# Altium schematic sheet MCU.SchDoc
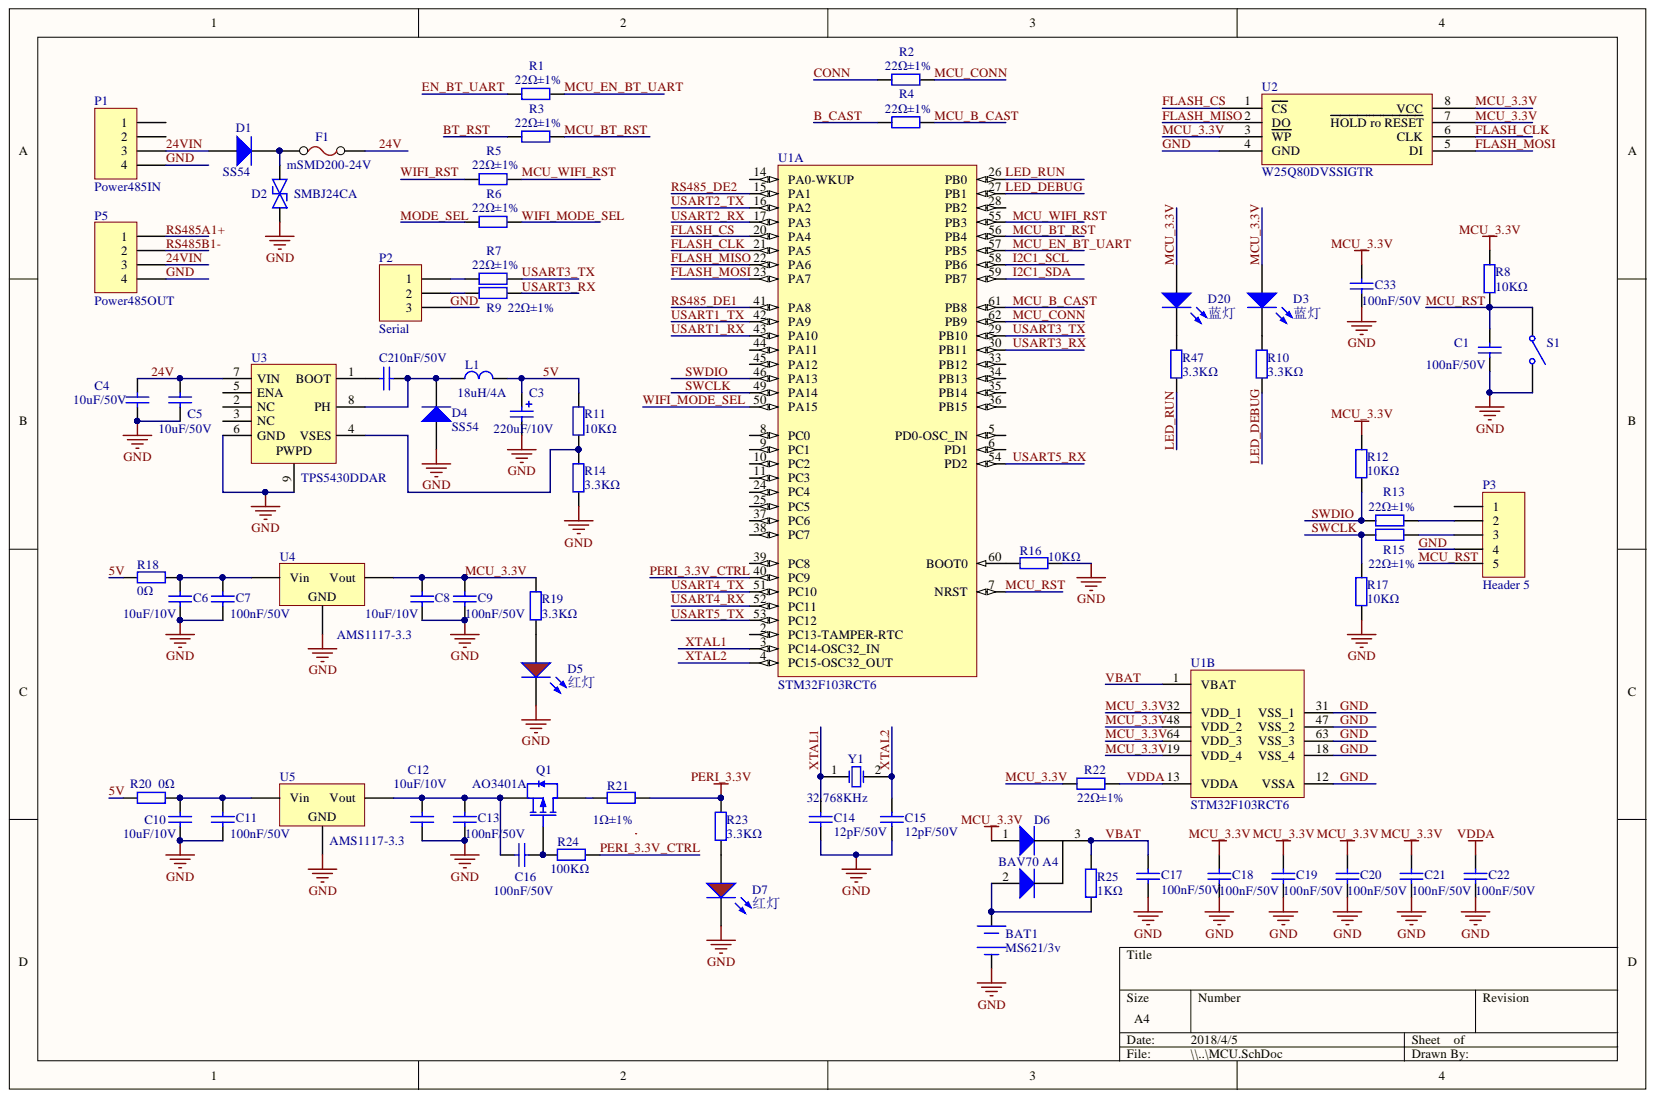
[diagram p0: MCU.SchDoc]

## Parts
BAT1  MS621/3v  [MS621]
C1  100nF/50V  [0603_C]
C2  10nF/50V  [0603_C]
C3  220uF/10V  [LC-CASE-D_7343]
C4  10uF/50V  [1206_C]
C5  10uF/50V  [1206_C]
C6  10uF/10V  [0603_C]
C7  100nF/50V  [0603_C]
C8  10uF/10V  [0603_C]
C9  100nF/50V  [0603_C]
C10  10uF/10V  [0603_C]
C11  100nF/50V  [0603_C]
C12  10uF/10V  [0603_C]
C13  100nF/50V  [0603_C]
C14  12pF/50V  [0603_C]
C15  12pF/50V  [0603_C]
C16  100nF/50V  [0603_C]
C17  100nF/50V  [0603_C]
C18  100nF/50V  [0603_C]
C19  100nF/50V  [0603_C]
C20  100nF/50V  [0603_C]
C21  100nF/50V  [0603_C]
C22  100nF/50V  [0603_C]
C33  100nF/50V  [0603_C]
D1  SS54  [SMA(DO-214AC)_S1]
D2  SMBJ24CA  [SMB(DO-214AA)_S1]
D3  蓝灯  [0603_LED_S1]
D4  SS54  [SMA(DO-214AC)_S1]
D5  红灯  [0603_LED_S1]
D6  BAV70 A4  [SOT-23(SOT-23-3)]
D7  红灯  [0603_LED_S1]
D20  蓝灯  [0603_LED_S1]
F1  mSMD200-24V  [LC-1812]
L1  18uH/4A  [L127]
P1  Power485IN  [15EDG-3.81MM-4P]
P2  Serial  [HDR1X3]
P3  Header 5  [HDR1X5]
P5  Power485OUT  [15EDG-3.81MM-4P]
Q1  AO3401A  [SOT-23-3L]
R1  22Ω±1%  [0603_R]
R2  22Ω±1%  [0603_R]
R3  22Ω±1%  [0603_R]
R4  22Ω±1%  [0603_R]
R5  22Ω±1%  [0603_R]
R6  22Ω±1%  [0603_R]
R7  22Ω±1%  [0603_R]
R8  10KΩ  [0603_R]
R9  22Ω±1%  [0603_R]
R10  3.3KΩ  [0603_R]
R11  10KΩ  [0603_R]
R12  10KΩ  [0603_R]
R13  22Ω±1%  [0603_R]
R14  3.3KΩ  [0603_R]
R15  22Ω±1%  [0603_R]
R16  10KΩ  [0603_R]
R17  10KΩ  [0603_R]
R18  0Ω  [0603_R]
R19  3.3KΩ  [0603_R]
R20  0Ω  [0603_R]
R21  1Ω±1%  [0603_R]
R22  22Ω±1%  [0603_R]
R23  3.3KΩ  [0603_R]
R24  100KΩ  [0603_R]
R25  1KΩ  [0603_R]
R47  3.3KΩ  [0603_R]
S1  (no value)  [KEY_6X6]
U1  STM32F103RCT6  [LQFP-64_10x10x05P]  (parts A,B)
U2  W25Q80DVSSIGTR  [SOIC-8_208mil]
U3  TPS5430DDAR  [SOP-8_EP_150mil_GND]
U4  AMS1117-3.3  [SOT-223]
U5  AMS1117-3.3  [SOT-223]
Y1  32.768KHz  [SMD-3215_2P FC - 135]

## Nets
PERI_3.3V: R21.2 R23.2
5V: C3.1 L1.2 R11.2 R18.1 R20.1
24V: C4.2 C5.2 F1.2 U3.7(VIN)
24VIN: D1.1 P1.3 P5.3
B_CAST: R4.1
BT_RST: R3.1
CONN: R2.1
EN_BT_UART: R1.1
FLASH_CLK: U1.21(PA5) U2.6(CLK)
FLASH_CS: U1.20(PA4) U2.1(CS)
FLASH_MISO: U1.22(PA6) U2.2(DO)
FLASH_MOSI: U1.23(PA7) U2.5(DI)
GND: BAT1.2 C1.1 C3.2 C4.1 C5.1 C6.1 C7.1 C8.1 C9.1 C10.1 C11.1 C12.1 C13.1 C14.1 C15.1 C17.1 C18.1 C19.1 C20.1 C21.1 C22.1 C33.1 D2.1 D4.1 D5.K D7.K P1.4 P2.3 P3.4 P5.4 R14.1 R16.1 R17.1 S1.2 U1.12(VSSA) U1.18(VSS_4) U1.31(VSS_1) U1.47(VSS_2) U1.63(VSS_3) U2.4(GND) U3.6(GND) U3.9 U4.1 U5.1
I2C1_SCL: U1.58(PB6)
I2C1_SDA: U1.59(PB7)
LED_DEBUG: R10.1 U1.27(PB1)
LED_RUN: R47.1 U1.26(PB0)
MCU_3.3V: C8.2 C9.2 C18.2 C19.2 C20.2 C21.2 C33.2 D3.A D6.1 D20.A R8.2 R12.2 R19.2 R22.1 U1.19(VDD_4) U1.32(VDD_1) U1.48(VDD_2) U1.64(VDD_3) U2.3(WP) U2.7(HOLD_ro_RESET) U2.8(VCC) U4.2
MCU_B_CAST: R4.2 U1.61(PB8)
MCU_BT_RST: R3.2 U1.56(PB4)
MCU_CONN: R2.2 U1.62(PB9)
MCU_EN_BT_UART: R1.2 U1.57(PB5)
MCU_RST: C1.2 P3.5 R8.1 S1.1 U1.7(NRST)
MCU_WIFI_RST: R5.2 U1.55(PB3)
MODE_SEL: R6.1
PERI_3.3V_CTRL: R24.2 U1.40(PC9)
RS485_DE1: U1.41(PA8)
RS485_DE2: U1.15(PA1)
RS485A1+: P5.1
RS485B1-: P5.2
SWCLK: R15.1 R17.2 U1.49(PA14)
SWDIO: R12.1 R13.1 U1.46(PA13)
USART1_RX: U1.43(PA10)
USART1_TX: U1.42(PA9)
USART2_RX: U1.17(PA3)
USART2_TX: U1.16(PA2)
USART3_RX: R9.2 U1.30(PB11)
USART3_TX: R7.2 U1.29(PB10)
USART4_RX: U1.52(PC11)
USART4_TX: U1.51(PC10)
USART5_RX: U1.54(PD2)
USART5_TX: U1.53(PC12)
VBAT: C17.2 D6.3 R25.2 U1.1(VBAT)
VDDA: C22.2 R22.2 U1.13(VDDA)
WIFI_MODE_SEL: R6.2 U1.50(PA15)
WIFI_RST: R5.1
XTAL1: C14.2 U1.3(PC14-OSC32_IN) Y1.1
XTAL2: C15.2 U1.4(PC15-OSC32_OUT) Y1.2
NetBAT1_1: BAT1.1 D6.2 R25.1
NetC2_1: C2.1 D4.2 L1.1 U3.8(PH)
NetC2_2: C2.2 U3.1(BOOT)
NetC6_2: C6.2 C7.2 R18.2 U4.3
NetC10_2: C10.2 C11.2 R20.2 U5.3
NetC12_2: C12.2 C13.2 C16.1 Q1.2 U5.2
NetC16_2: C16.2 Q1.1 R24.1
NetD1_2: D1.2 D2.2 F1.1
NetD3_K: D3.K R10.2
NetD5_A: D5.A R19.1
NetD7_A: D7.A R23.1
NetD20_K: D20.K R47.2
NetP2_1: P2.1 R7.1
NetP2_2: P2.2 R9.1
NetP3_2: P3.2 R13.2
NetP3_3: P3.3 R15.2
NetQ1_3: Q1.3 R21.1
NetR11_1: R11.1 R14.2 U3.4(VSES)
NetR16_2: R16.2 U1.60(BOOT0)

Not connected: P1 1 2; P3 1; U1 2(PC13-TAMPER-RTC) 5(PD0-OSC_IN) 6(PD1) 8(PC0) 9(PC1) 10(PC2) 11(PC3) 14(PA0-WKUP) 24(PC4) 25(PC5) 28(PB2) 33(PB12) 34(PB13) 35(PB14) 36(PB15) 37(PC6) 38(PC7) 39(PC8) 44(PA11) 45(PA12); U3 2(NC) 3(NC) 5(ENA)

## Library data of the parts
BAT1 = POWER: Component Kind: Standard
C1 C7 C9 C11 C13 C16-C22 C33 = 0603 100nF (104) 10% 50V: 贴片电容; Component Kind: Standard; ComponentLink1Description: 供应商链接; ComponentLink1URL: http://www.szlcsc.com/product/details_15331.html; Library Name: Miscellaneous Devices LC.IntLib; Package: 0603; Supplier: LC; SuppliersPartNumber: C14663
C2 = 0603 10nF (103) 10% 50V: 贴片电容; Component Kind: Standard; ComponentLink1Description: 供应商链接; ComponentLink1URL: http://www.szlcsc.com/product/details_58143.html; Library Name: Miscellaneous Devices LC.IntLib; Package: 0603; Supplier: LC; SuppliersPartNumber: C57112
C3 = 3528 100uF (107) 10% 6.3V: 钽电容; Component Kind: Standard; Library Name: Miscellaneous Devices LC.IntLib; Package: 7343; Supplier: LC; SuppliersPartNumber: C116742
C4 C5 = 1206 10uF (106) 10% 50V: 贴片电容; Component Kind: Standard; ComponentLink1Description: 供应商链接; ComponentLink1URL: http://www.szlcsc.com/product/details_14236.html; Library Name: Miscellaneous Devices LC.IntLib; Package: 1206; Supplier: LC; SuppliersPartNumber: C13585
C6 C8 C10 C12 = 0603 10uF (106) 10% 10V: 贴片电容; Component Kind: Standard; ComponentLink1Description: 供应商链接; ComponentLink1URL: http://www.szlcsc.com/product/details_20411.html; Library Name: Miscellaneous Devices LC.IntLib; Package: 0603; Supplier: LC; SuppliersPartNumber: C19702
C14 C15 = 0603 12pF (120) 5% 50V: 贴片电容; Component Kind: Standard; ComponentLink1Description: 供应商链接; ComponentLink1URL: http://www.szlcsc.com/product/details_39506.html; Library Name: Miscellaneous Devices LC.IntLib; Package: 0603; Supplier: LC; SuppliersPartNumber: C38523
D1 D4 = SMA(DO-214AC) SS54: 二极管; Component Kind: Standard; ComponentLink1Description: 供应商链接; ComponentLink1URL: http://www.szlcsc.com/product/details_23178.html; Library Name: Miscellaneous Devices LC.IntLib; Package: SMA(DO-214AC); Supplier: LC; SuppliersPartNumber: C22452
D2 = TVS: Component Kind: Standard
D3 D20 = 0603 蓝灯: 发光二极管; Component Kind: Standard; ComponentLink1Description: 供应商链接; ComponentLink1URL: http://www.szlcsc.com/product/details_73150.html; Library Name: Miscellaneous Devices LC.IntLib; Package: 0603; Supplier: LC; SuppliersPartNumber: C72041
D5 D7 = 0603 红灯: 发光二极管; Component Kind: Standard; ComponentLink1Description: 供应商链接; ComponentLink1URL: http://www.szlcsc.com/product/details_2659.html; Library Name: Miscellaneous Devices LC.IntLib; Package: 0603; Supplier: LC; SuppliersPartNumber: C2286
D6 = SOT-23 BAV70 A4: 开关二极管; Component Kind: Standard; ComponentLink1Description: 供应商链接; ComponentLink1URL: http://www.szlcsc.com/product/details_70091.html; Library Name: Miscellaneous Devices LC.IntLib; Package: SOT-23; Supplier: LC; SuppliersPartNumber: C68978
F1 = FUSE: Value: 8V3A; Component Kind: Standard; Description: Fuse
L1 = INDUCTOR: Component Kind: Standard
P1 P5 = Header 4: Header, 4-Pin; Component Kind: Standard; LatestRevisionDate: 17-Jul-2002; LatestRevisionNote: Re-released for DXP Platform.; Library Name: Miscellaneous Connectors.IntLib; Publisher: Altium Limited
P2 = Header 3: Header, 3-Pin; Component Kind: Standard; LatestRevisionDate: 17-Jul-2002; LatestRevisionNote: Re-released for DXP Platform.; Library Name: Miscellaneous Connectors.IntLib; Publisher: Altium Limited
P3 = Header 5: Header, 5-Pin; Component Kind: Standard; LatestRevisionDate: 17-Jul-2002; LatestRevisionNote: Re-released for DXP Platform.; Library Name: Miscellaneous Connectors.IntLib; Publisher: Altium Limited
Q1 = AO3401A P道沟 X13A15 SOT-23: MOS(场效应管); Component Kind: Standard; ComponentLink1Description: 供应商链接; ComponentLink1URL: http://www.szlcsc.com/product/details_15801.html; Library Name: Miscellaneous Devices LC.IntLib; Package: SOT-23; Supplier: LC; SuppliersPartNumber: C15127
R1-R7 R9 R13 R15 R22 = 0603 22Ω (22R0) 1%: 贴片电阻; Component Kind: Standard; ComponentLink1Description: 供应商链接; ComponentLink1URL: http://www.szlcsc.com/product/details_24078.html; Library Name: Miscellaneous Devices LC.IntLib; Package: 0603; Supplier: LC; SuppliersPartNumber: C23345
R8 R11 R12 R16 R17 = 0603 10KΩ (1002) 1%: 贴片电阻; Component Kind: Standard; ComponentLink1Description: 供应商链接; ComponentLink1URL: http://www.szlcsc.com/product/details_26547.html; Library Name: Miscellaneous Devices LC.IntLib; Package: 0603; Supplier: LC; SuppliersPartNumber: C25804
R10 R14 R19 R23 R47 = 0603 3.3KΩ (3301) 1%: 贴片电阻; Component Kind: Standard; ComponentLink1Description: 供应商链接; ComponentLink1URL: http://www.szlcsc.com/product/details_23705.html; Library Name: Miscellaneous Devices LC.IntLib; Package: 0603; Supplier: LC; SuppliersPartNumber: C22978
R18 R20 = 0603 0Ω (0R0) 1%: 贴片电阻; Component Kind: Standard; ComponentLink1Description: 供应商链接; ComponentLink1URL: http://www.szlcsc.com/product/details_21903.html; Library Name: Miscellaneous Devices LC.IntLib; Package: 0603; Supplier: LC; SuppliersPartNumber: C21189
R21 = 0603 1Ω (1R00) 1%: 贴片电阻; Component Kind: Standard; ComponentLink1Description: 供应商链接; ComponentLink1URL: http://www.szlcsc.com/product/details_23663.html; Library Name: Miscellaneous Devices LC.IntLib; Package: 0603; Supplier: LC; SuppliersPartNumber: C22936
R24 = 0603 100KΩ (1003) 1%: 贴片电阻; Component Kind: Standard; ComponentLink1Description: 供应商链接; ComponentLink1URL: http://www.szlcsc.com/product/details_26546.html; Library Name: Miscellaneous Devices LC.IntLib; Package: 0603; Supplier: LC; SuppliersPartNumber: C25803
R25 = 0603 1KΩ (1001) 1%: 贴片电阻; Component Kind: Standard; ComponentLink1Description: 供应商链接; ComponentLink1URL: http://www.szlcsc.com/product/details_21904.html; Library Name: Miscellaneous Devices LC.IntLib; Package: 0603; Supplier: LC; SuppliersPartNumber: C21190
S1 = SW-SPST: Single-Pole, Single-Throw Switch; Component Kind: Standard; LatestRevisionDate: 17-Jul-2002; LatestRevisionNote: Re-released for DXP Platform.; Library Name: Miscellaneous Devices.IntLib; PackageReference: SPST-2; Published: 24-Mar-1999; Publisher: Altium Limited
U1 = STM32F103RCT6: ARM; Component Kind: Standard; ComponentLink1Description: 供应商链接; ComponentLink1URL: http://www.szlcsc.com/product/details_8815.html; Library Name: Miscellaneous Devices LC.IntLib; Package: LQFP-64_10x10x05P; Supplier: LC; SuppliersPartNumber: C8323
U2 = W25Q128FVSIGTR: Flash ROM; Component Kind: Standard; ComponentLink1Description: 供应商链接; ComponentLink1URL: http://item.szlcsc.com/99372.html; Library Name: Miscellaneous Devices LC.IntLib; Package: SOIC-8; Supplier: LC; SuppliersPartNumber: C110643
U3 = TPS5430DDAR: DC-DC芯片; Component Kind: Standard; ComponentLink1Description: 供应商链接; ComponentLink1URL: http://www.szlcsc.com/product/details_10396.html; Package: SOP-8_EP_150mil; Supplier: LC; SuppliersPartNumber: C9864; Library Name: LYL_lib.SCHLIB
U4 U5 = SOT-223 AMS1117-3.3: 低压差线性稳压(LDO); Component Kind: Standard; ComponentLink1Description: 供应商链接; ComponentLink1URL: http://www.szlcsc.com/product/details_6652.html; Library Name: Miscellaneous Devices LC.IntLib; Package: SOT-223; Supplier: LC; SuppliersPartNumber: C6186
Y1 = SMD-3215_2P 32.768KHz 20ppm 12.5pF: 贴片晶振; Component Kind: Standard; ComponentLink1Description: 供应商链接; ComponentLink1URL: http://www.szlcsc.com/product/details_33310.html; Library Name: Miscellaneous Devices LC.IntLib; Package: SMD-3215_2P; Supplier: LC; SuppliersPartNumber: C32346
